# Altium schematic sheet Lazy_Control_Board_1_0_C.SchDoc(Lazy_Control_Board_1_0_C)
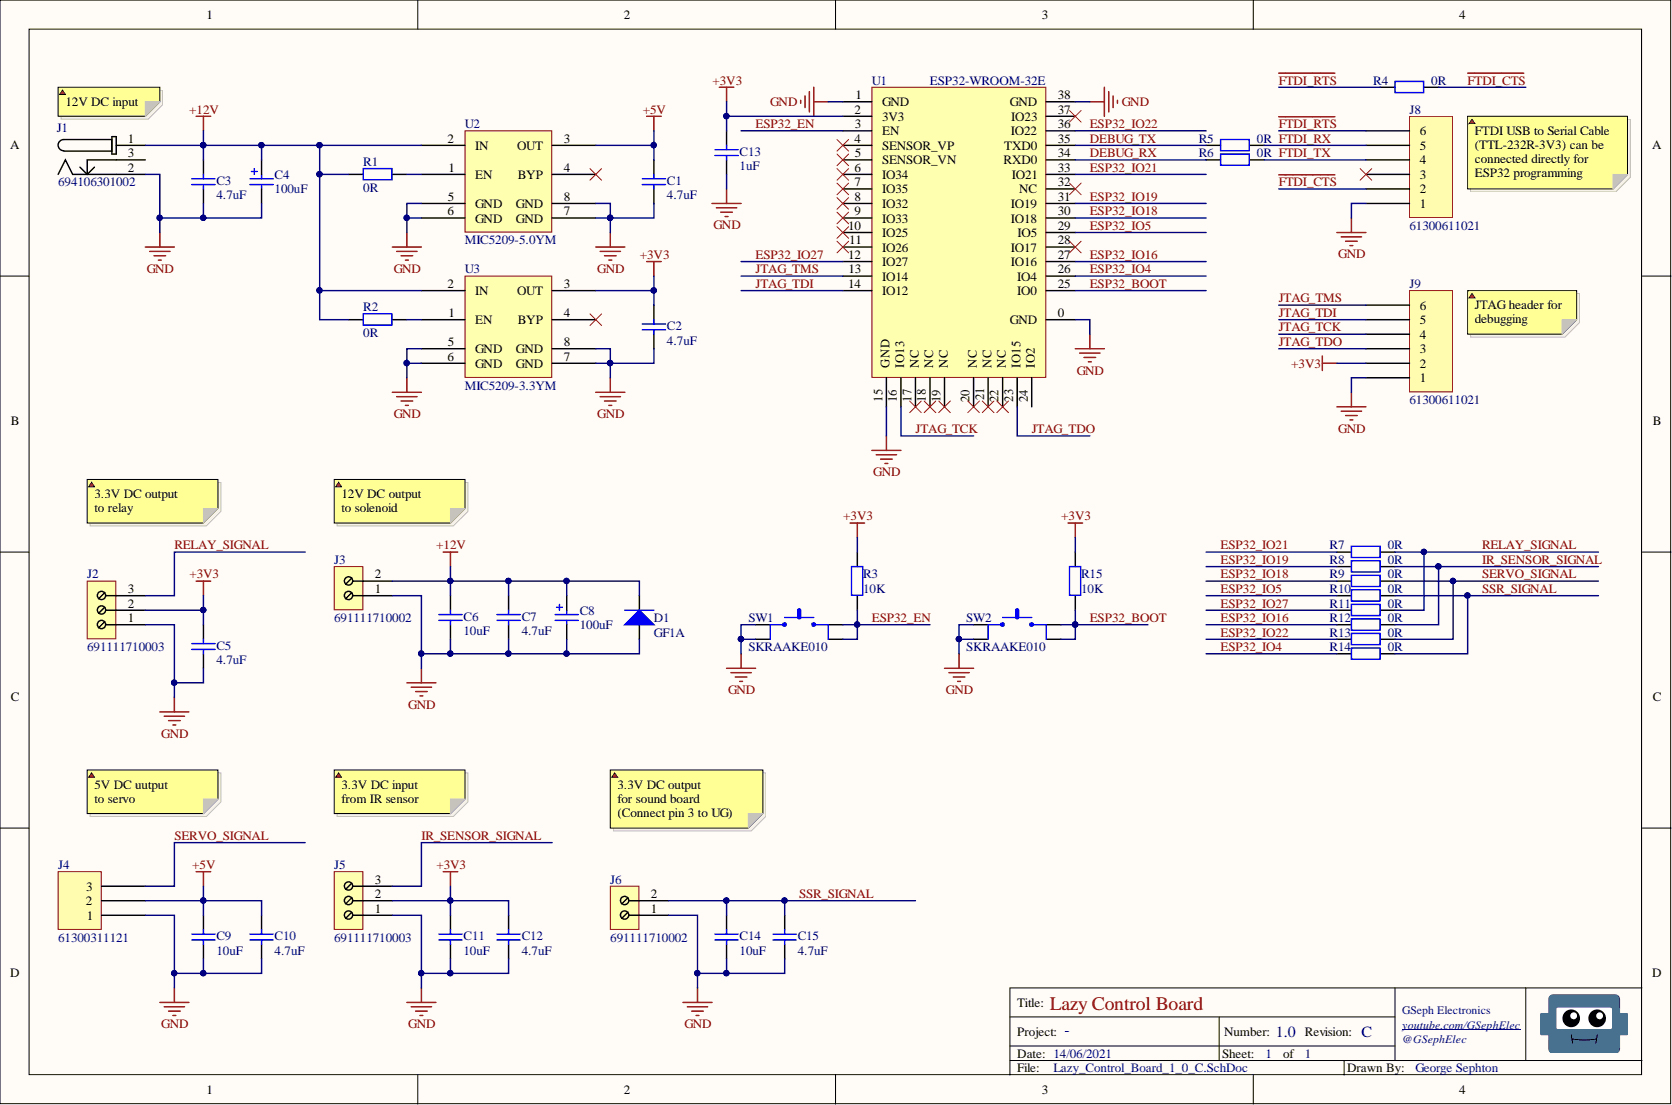
[diagram p0: Lazy_Control_Board_1_0_C.SchDoc]

## Parts
C1  4.7uF  [G_CAPC1608]
C2  4.7uF  [G_CAPC1608]
C3  4.7uF  [G_CAPC1608]
C4  100uF  [WCAP-ASLL_6.3x5.5]
C5  4.7uF  [G_CAPC1608]
C6  10uF  [G_CAPC2012]
C7  4.7uF  [G_CAPC1608]
C8  100uF  [WCAP-ASLL_6.3x5.5]
C9  10uF  [G_CAPC2012]
C10  4.7uF  [G_CAPC1608]
C11  10uF  [G_CAPC2012]
C12  4.7uF  [G_CAPC1608]
C13  1uF  [G_CAPC1608]
C14  10uF  [G_CAPC2012]
C15  4.7uF  [G_CAPC1608]
D1  (no value)  [G_SMA]
J1  (no value)  [694106301002]
J2  (no value)  [691111710003]
J3  (no value)  [691111710002]
J4  (no value)  [61300311121]
J5  (no value)  [691111710003]
J6  (no value)  [691111710002]
J8  (no value)  [61300611021]
J9  (no value)  [61300611021]
R1  0R  [G_RESC1608]
R2  0R  [G_RESC1608]
R3  10K  [G_RESC1608]
R4  0R  [G_RESC1608]
R5  0R  [G_RESC1608]
R6  0R  [G_RESC1608]
R7  0R  [G_RESC1608]
R8  0R  [G_RESC1608]
R9  0R  [G_RESC1608]
R10  0R  [G_RESC1608]
R11  0R  [G_RESC1608]
R12  0R  [G_RESC1608]
R13  0R  [G_RESC1608]
R14  0R  [G_RESC1608]
R15  10K  [G_RESC1608]
SW1  (no value)  [SKRAAKE010]
SW2  (no value)  [SKRAAKE010]
U1  Part: ESP32-WROOM-32E  [ESP32-WROOM-32D]
U2  Part: MIC5209-5.0YM  [G_SOIC_8C]
U3  Part: MIC5209-3.3YM  [G_SOIC_8C]

## Nets
+3V3: C2.2 C5.2 C11.2 C12.2 C13.2 J2.2 J5.2 J9.2 R3.2 R15.2 U1.2(3V3) U3.3(OUT)
+5V: C1.2 C9.2 C10.2 J4.2 U2.3(OUT)
+12V: C3.2 C4.2 C6.2 C7.2 C8.2 D1.2 J1.1 J3.2 R1.1 R2.1 U2.2(IN) U3.2(IN)
GND: C1.1 C2.1 C3.1 C4.1 C5.1 C6.1 C7.1 C8.1 C9.1 C10.1 C11.1 C12.1 C13.1 C14.1 C15.1 D1.1 J1.2 J2.1 J3.1 J4.1 J5.1 J6.1 J8.1 J9.1 SW1.1 SW1.2 SW2.1 SW2.2 U1.0(GND) U1.1(GND) U1.15(GND) U1.38(GND) U2.5(GND) U2.6(GND) U2.7(GND) U2.8(GND) U3.5(GND) U3.6(GND) U3.7(GND) U3.8(GND)
DEBUG_RX: R6.1 U1.34(RXD0)
DEBUG_TX: R5.1 U1.35(TXD0)
ESP32_BOOT: R15.1 SW2.3 SW2.4 U1.25(IO0)
ESP32_EN: R3.1 SW1.3 SW1.4 U1.3(EN)
ESP32_IO4: R14.1 U1.26(IO4)
ESP32_IO5: R10.1 U1.29(IO5)
ESP32_IO16: R12.1 U1.27(IO16)
ESP32_IO18: R9.1 U1.30(IO18)
ESP32_IO19: R8.1 U1.31(IO19)
ESP32_IO21: R7.1 U1.33(IO21)
ESP32_IO22: R13.1 U1.36(IO22)
ESP32_IO27: R11.1 U1.12(IO27)
~{FTDI_CTS}: J8.2 R4.2
~{FTDI_RTS}: J8.6 R4.1
FTDI_RX: J8.5 R5.2
FTDI_TX: J8.4 R6.2
IR_SENSOR_SIGNAL: J5.3 R8.2 R12.2
JTAG_TCK: J9.4 U1.16(IO13)
JTAG_TDI: J9.5 U1.14(IO12)
JTAG_TDO: J9.3 U1.23(IO15)
JTAG_TMS: J9.6 U1.13(IO14)
RELAY_SIGNAL: J2.3 R7.2 R11.2
SERVO_SIGNAL: J4.3 R9.2 R13.2
SSR_SIGNAL: C14.2 C15.2 J6.2 R10.2 R14.2
NetR1_2: R1.2 U2.1(EN)
NetR2_2: R2.2 U3.1(EN)

Not connected: J1 3; J8 3; U1 4(SENSOR_VP) 5(SENSOR_VN) 6(IO34) 7(IO35) 8(IO32) 9(IO33) 10(IO25) 11(IO26) 17(NC) 18(NC) 19(NC) 20(NC) 21(NC) 22(NC) 24(IO2) 28(IO17) 32(NC) 37(IO23); U2 4(BYP); U3 4(BYP)

## Library data of the parts
C1-C3 C5 C7 C10 C12 C13 C15 = G_CAP_X5R_0603: Multilayer Ceramic Ceramic Capacitor SMD 0603 X5R 16V 10%; Fitted: Yes; Component Kind: Standard; Library Name: GSephElec.SchLib
C4 C8 = 865060343005: WCAP-ASLL SMT Aluminum Electrolytic Capacitors, D6.3mm x L5.5mm, 100uF, +/-20%, 16VDC; Manufacturer: Wurth Elektronik; Fitted: Yes; MPN: 865060343005; Component Kind: Standard; Library Name: GSephElec.SchLib
C6 C9 C11 C14 = G_CAP_X5R_0805: Multilayer Ceramic Ceramic Capacitor SMD 0805 X5R 16V 10%; Fitted: Yes; Component Kind: Standard; Library Name: GSephElec.SchLib
D1 = GF1A: Standard Recovery Diode 50V 1A Single 1V 2 µs 30A; Manufacturer: On Semi; Fitted: Yes; Part: GF1A; MPN: GF1A; Component Kind: Standard; Library Name: GSephElec.SchLib
J1 = 694106301002: WR-DC DC Power Jack Connector, Male, Right Angled, 24V, 5A; Manufacturer: Wurth Elektronik; Fitted: Yes; Part: 694106301002; MPN: 694106301002; Component Kind: Standard; Library Name: GSephElec.SchLib
J2 J5 = 691111710003: WR-TBL Serie 111 Horizontal Entry Modular, Pressure Clamp, THT, pitch 5mm, 3p; Manufacturer: Wurth Elektronik; Fitted: Yes; Part: 691111710003; MPN: 691111710003; Component Kind: Standard; Library Name: GSephElec.SchLib
J3 J6 = 691111710002: WR-TBL Serie 111 Horizontal Entry Modular, Pressure Clamp, THT, pitch 5mm, 2p; Manufacturer: Wurth Elektronik; Fitted: Yes; Part: 691111710002; MPN: 691111710002; Component Kind: Standard; Library Name: GSephElec.SchLib
J4 = 61300311121: WR-PHD Pin Header, THT, pitch 2.54mm, Single Row, Straight, 3p; Manufacturer: Wurth Elektronik; Fitted: Yes; Part: 61300311121; MPN: 61300311121; Component Kind: Standard; Library Name: GSephElec.SchLib
J8 J9 = 61300611021: WR-PHD Pin Header, THT, pitch 2.54mm, Single Row, Angled, 6p; Manufacturer: Wurth Elektronik; Fitted: Yes; Part: 61300611021; MPN: 61300611021; Component Kind: Standard; Library Name: GSephElec.SchLib
R1-R10 R15 = G_RES_0603: Thick Film Resistor SMD 0603 1% 0.1W; Fitted: Yes; Component Kind: Standard; Library Name: GSephElec.SchLib
R11-R14 = G_RES_0603: Thick Film Resistor SMD 0603 1% 0.1W; Fitted: No; Component Kind: Standard; Library Name: GSephElec.SchLib
SW1 SW2 = SKRAAKE010: Alps Tactile Switche 6.2x6.2x3.4mm 250gf; Fitted: Yes; Part: SKRAAKE010; MPN: SKRAAKE010; Manufacturer: Alps; Component Kind: Standard; Library Name: GSephElec.SchLib
U1 = ESP32-WROOM-32: ESP32 ECO V3 SMD module, 4 MB SPI Flash, PCB Antenna; MPN: ESP32-WROOM-32E(M113EH3200PH3Q0); Manufacturer: Espressif; Fitted: Yes; Component Kind: Standard; Library Name: GSephElec.SchLib
U2 = MIC5209: 500mA 1% Accuracy Low Noise LDO; MPN: MIC5209-3.3YM; Manufacturer: Microchip; Fitted: Yes; Component Kind: Standard; Library Name: GSephElec.SchLib
U3 = MIC5209: 500mA 1% Accuracy Low Noise LDO; Manufacturer: Microchip; Fitted: Yes; MPN: MIC5209-3.3YM; Component Kind: Standard; Library Name: GSephElec.SchLib
